# Altium schematic sheet WIZ810S_IO.SchDoc
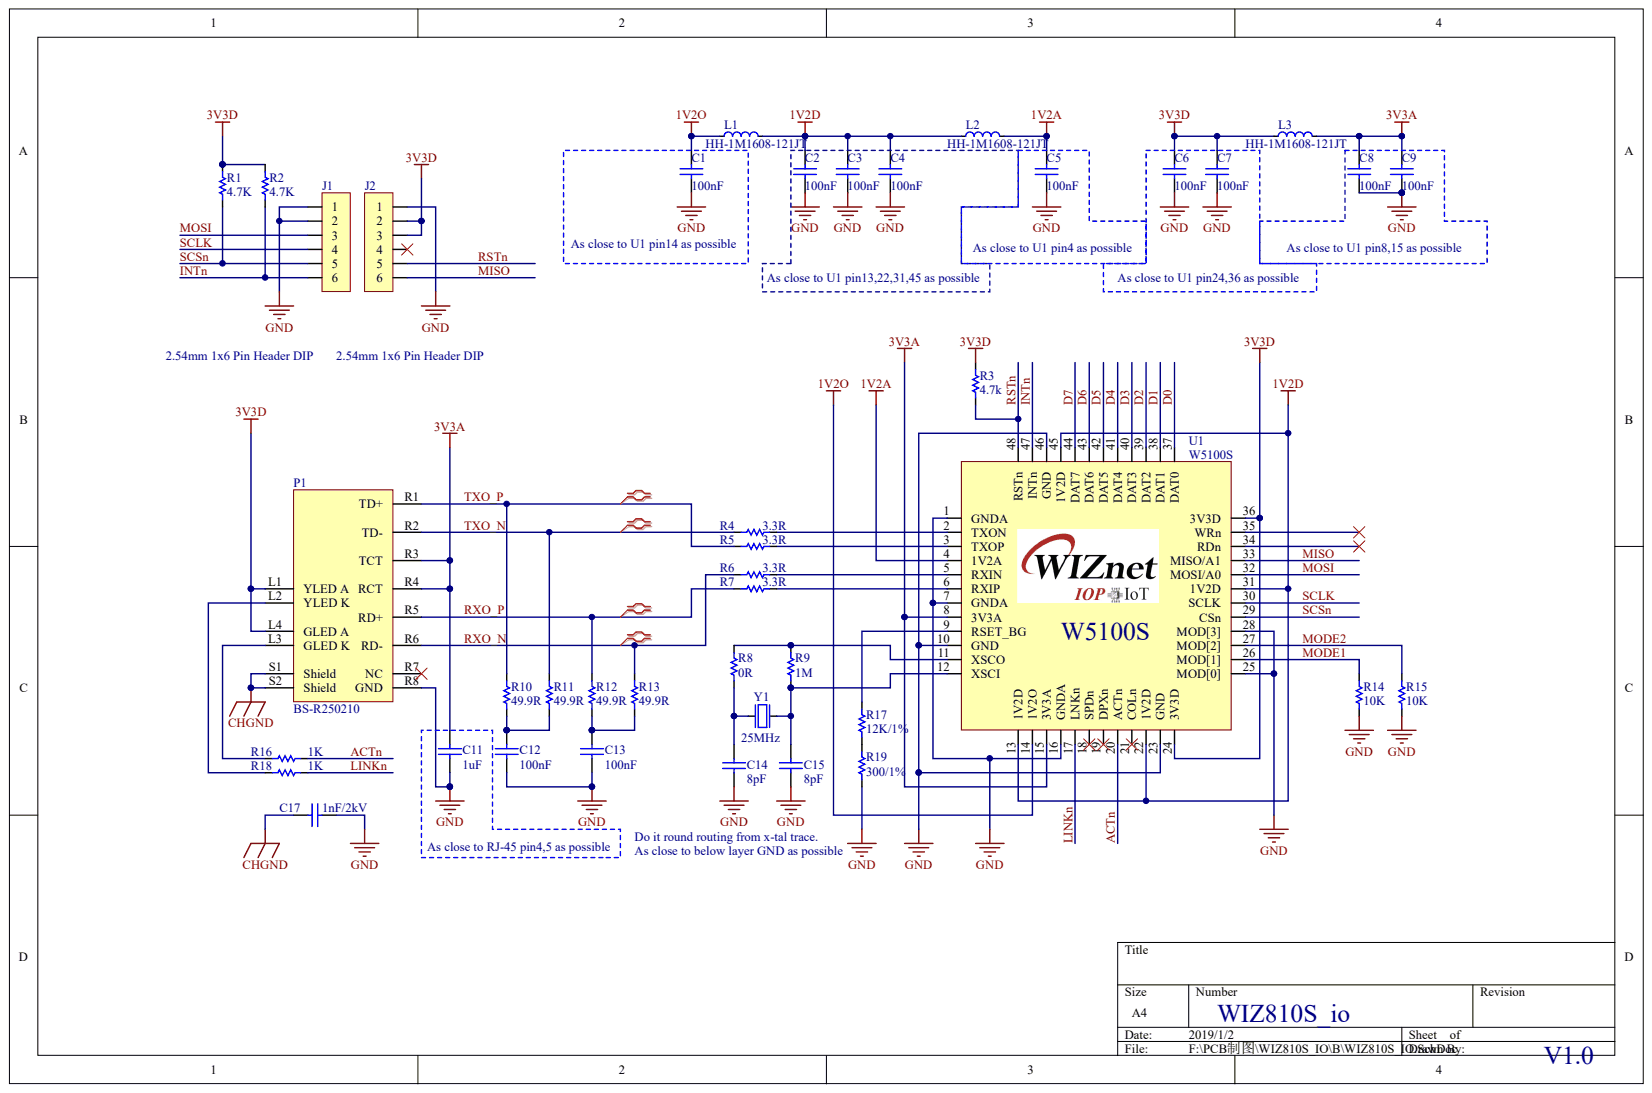
[diagram p0: WIZ810S_IO.SchDoc]

## Parts
C1  100nF
C2  100nF
C3  100nF
C4  100nF
C5  100nF
C6  100nF
C7  100nF
C8  100nF
C9  100nF
C11  1uF
C12  100nF
C13  100nF
C14  8pF
C15  8pF
C17  1nF/2kV
J1  2.54mm 1x6 Pin Header DIP
J2  2.54mm 1x6 Pin Header DIP
L1  HH-1M1608-121JT
L2  HH-1M1608-121JT
L3  HH-1M1608-121JT
P1  BS-R250210
R1  4.7K
R2  4.7K
R3  4.7k
R4  3.3R
R5  3.3R
R6  3.3R
R7  3.3R
R8  0R
R9  1M
R10  49.9R
R11  49.9R
R12  49.9R
R13  49.9R
R14  10K
R15  10K
R16  1K
R17  12K/1%
R18  1K
R19  300/1%
U1  W5100S
Y1  25MHz

## Nets
1V2A: C5.2 L2.2 U1.4(1V2A)
1V2D: C2.2 C3.2 C4.2 L1.2 L2.1 U1.13(1V2D) U1.22(1V2D) U1.31(1V2D) U1.45(1V2D)
1V2O: C1.2 L1.1 U1.14(1V2O)
3V3A: C8.2 C9.2 C11.2 L3.2 P1.R3(TCT) P1.R4(RCT) U1.8(3V3A) U1.15(3V3A)
3V3D: C6.2 C7.2 J2.2 J2.3 L3.1 P1.L1 P1.L4(GLED_A) R1.1 R2.1 R3.2 U1.24(3V3D) U1.36(3V3D)
CHGND: C17.1 P1.S1(Shield) P1.S2(Shield)
GND: C1.1 C2.1 C3.1 C4.1 C5.1 C6.1 C7.1 C8.1 C9.1 C11.1 C12.1 C13.1 C14.1 C15.1 C17.2 J1.1 J1.2 J2.1 P1.R8(GND) R14.1 R15.1 R19.1 U1.1(GNDA) U1.7(GNDA) U1.10(GND) U1.16(GNDA) U1.23(GND) U1.25(MOD[0]) U1.28(MOD[3]) U1.46(GND) Y1.2 Y1.4
ACTN: R16.1 U1.20(ACTn)
D0: U1.37(DAT0)
D1: U1.38(DAT1)
D2: U1.39(DAT2)
D3: U1.40(DAT3)
D4: U1.41(DAT4)
D5: U1.42(DAT5)
D6: U1.43(DAT6)
D7: U1.44(DAT7)
INTN: J1.6 R2.2 U1.47(INTn)
LINKN: R18.1 U1.17(LNKn)
MISO: J2.6 U1.33(MISO/A1)
MODE1: R14.2 U1.26(MOD[1])
MODE2: R15.2 U1.27(MOD[2])
MOSI: J1.3 U1.32(MOSI/A0)
RSTN: J2.5 R3.1 U1.48(RSTn)
RXO_N: P1.R6(RD-) R6.2 R13.2
RXO_P: P1.R5(RD+) R7.2 R12.2
SCLK: J1.4 U1.30(SCLK)
SCSN: J1.5 R1.2 U1.29(CSn)
TXO_N: P1.R2(TD-) R4.2 R11.2
TXO_P: P1.R1(TD+) R5.2 R10.2
NetC12_2: C12.2 R10.1 R11.1
NetC13_2: C13.2 R12.1 R13.1
NetC14_2: C14.2 R8.1 Y1.1
NetC15_2: C15.2 R9.1 U1.12(XSCI) Y1.3
NetP1_L2: P1.L2(YLED_K) R18.2
NetP1_L3: P1.L3 R16.2
NetR4_1: R4.1 U1.2(TXON)
NetR5_1: R5.1 U1.3(TXOP)
NetR6_1: R6.1 U1.5(RXIN)
NetR7_1: R7.1 U1.6(RXIP)
NetR8_2: R8.2 R9.2 U1.11(XSCO)
NetR17_1: R17.1 R19.2
NetR17_2: R17.2 U1.9(RSET_BG)

Not connected: J2 4; P1 R7(NC); U1 18(SPDn) 19(DPXn) 21(COLn) 34(RDn) 35(WRn)
